# Altium schematic sheet BL702.SchDoc(BL702)
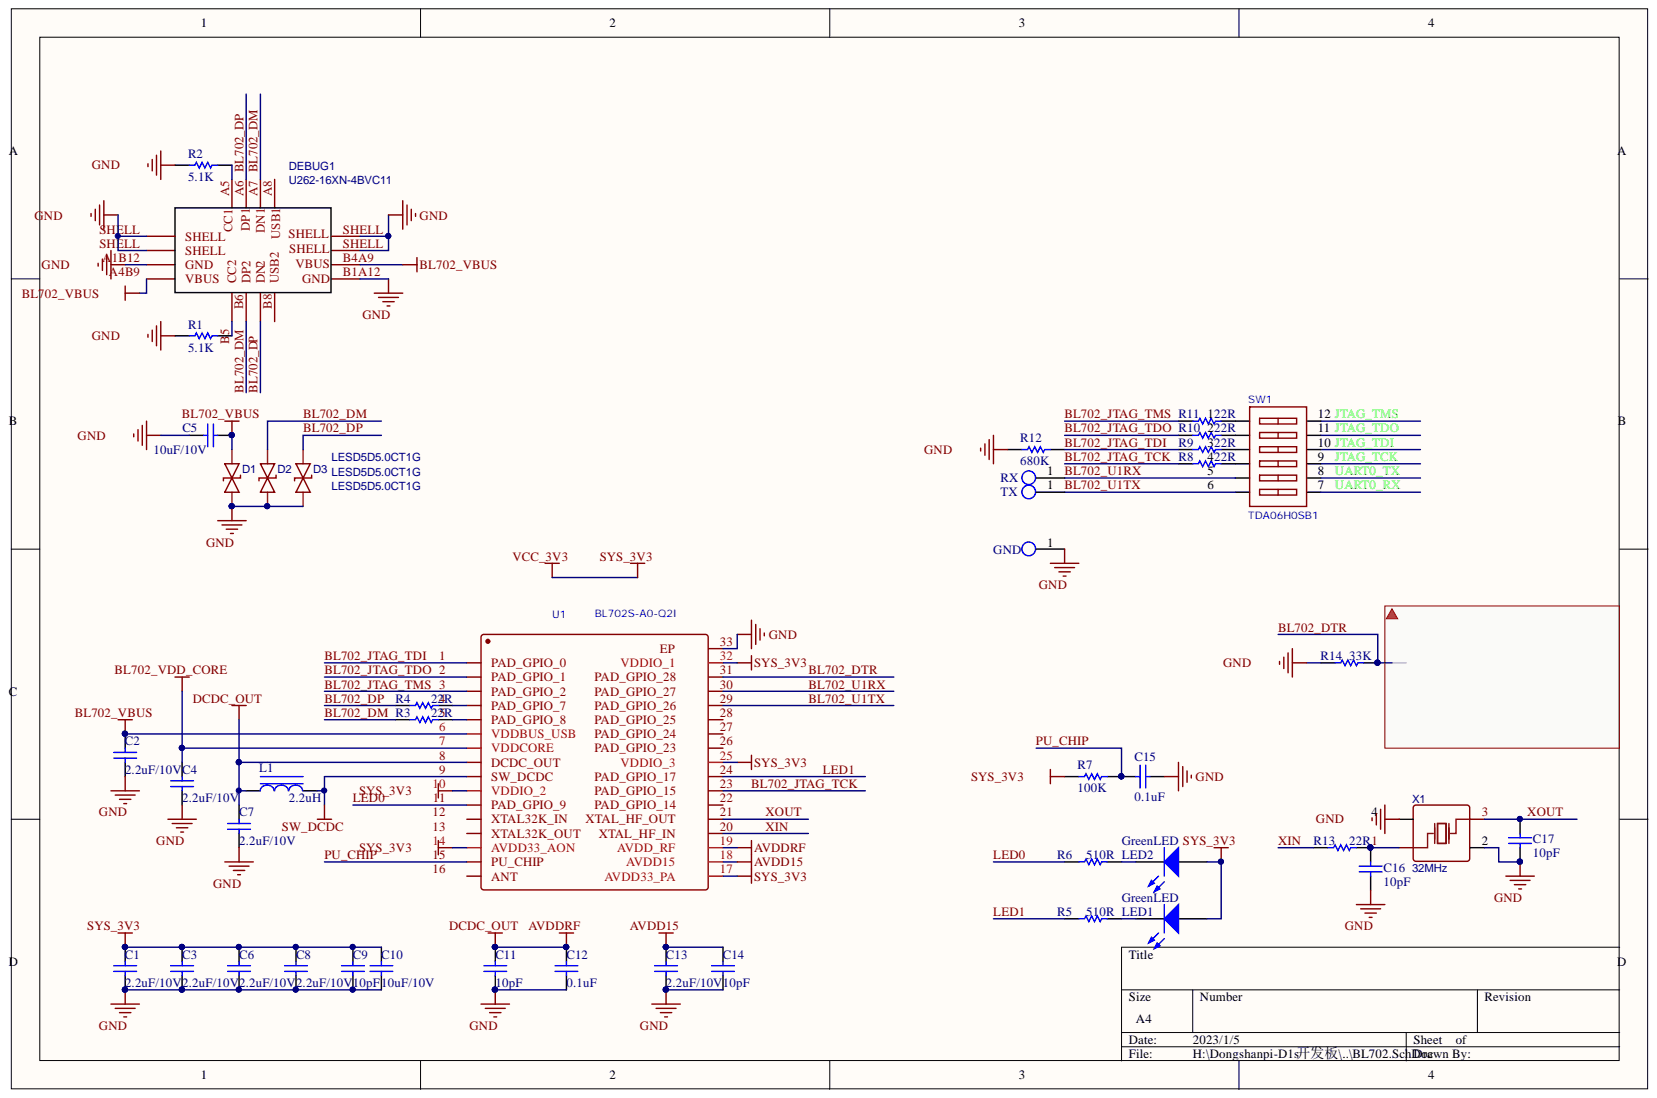
[diagram p0: BL702.SchDoc]

## Parts
C1  2.2uF/10V  [0402]
C2  2.2uF/10V  [0402]
C3  2.2uF/10V  [0402]
C4  2.2uF/10V  [0402]
C5  10uF/10V  [0402]
C6  2.2uF/10V  [0402]
C7  2.2uF/10V  [0402]
C8  2.2uF/10V  [0402]
C9  10pF  [0402]
C10  10uF/10V  [0402]
C11  10pF  [0402]
C12  0.1uF  [0402]
C13  2.2uF/10V  [0402]
C14  10pF  [0402]
C15  0.1uF  [0402]
C16  10pF  [0402]
C17  10pF  [0402]
D1  LESD5D5.0CT1G  [SOD-523_L1.2-W0.8-LS1.6-BI]
D2  LESD5D5.0CT1G  [SOD-523_L1.2-W0.8-LS1.6-BI]
D3  LESD5D5.0CT1G  [SOD-523_L1.2-W0.8-LS1.6-BI]
DEBUG1  U262-16XN-4BVC11  [TYPEC-12PIN - duplicate]
GND  Value  [TP_TH_0.8_1.4]  (value not drawn)
L1  2.2uH  [VLS2016]
LED1  GreenLED  [LED0402]
LED2  GreenLED  [LED0402]
R1  5.1K  [0402]
R2  5.1K  [0402]
R3  22R  [0402]
R4  22R  [0402]
R5  510R  [0402]
R6  510R  [0402]
R7  100K  [0402]
R8  22R  [0402]
R9  22R  [0402]
R10  22R  [0402]
R11  22R  [0402]
R12  680K  [0402]
R13  22R  [0402]
R14  33K  [0402]
RX  Value  [TP_TH_0.8_1.4]  (value not drawn)
SW1  TDA06H0SB1  [SW-SMD_TDA06H0SB1]
TX  Value  [TP_TH_0.8_1.4]  (value not drawn)
U1  BL702S-A0-Q2I  [QFN-32_L4.0-W4.0-P0.40-TL-EP2.9]
X1  32MHz  [OSC-SMD_4P-L3.2-W2.5-BL]

## Nets
AVDD15: C13.2 C14.2 U1.18
AVDDRF: C7.2 C11.2 C12.2 L1.2 U1.8 U1.19
BL702_VBUS: C2.2 C5.2 D1.2 DEBUG1.A4B9 DEBUG1.B4A9 U1.6
BL702_VDD_CORE: C4.2 U1.7
GND: C1.1 C2.1 C3.1 C4.1 C5.1 C6.1 C7.1 C8.1 C9.1 C10.1 C11.1 C12.1 C13.1 C14.1 C15.1 C16.1 C17.1 D1.1 D2.1 D3.1 DEBUG1.A1B12 DEBUG1.B1A12 DEBUG1.SHELL GND.1 R1.2 R2.2 R12.1 R14.2 U1.33 X1.2 X1.4
SW_DCDC: L1.1 U1.9
SYS_3V3: C1.2 C3.2 C6.2 C8.2 C9.2 C10.2 LED1.1 LED2.1 R7.1 U1.10 U1.14 U1.17 U1.25 U1.32
BL702_DM: D2.2 DEBUG1.A7 DEBUG1.B7 R3.2
BL702_DP: D3.2 DEBUG1.A6 DEBUG1.B6 R4.2
BL702_DTR: R14.1 U1.31
BL702_JTAG_TCK: R8.2 U1.23
BL702_JTAG_TDI: R9.2 R12.2 U1.1
BL702_JTAG_TDO: R10.2 U1.2
BL702_JTAG_TMS: R11.2 U1.3
BL702_U1RX: RX.1 SW1.5 U1.30
BL702_U1TX: SW1.6 TX.1 U1.29
JTAG_TCK: SW1.9
JTAG_TDI: SW1.10
JTAG_TDO: SW1.11
JTAG_TMS: SW1.12
LED0: R6.1 U1.11
LED1: R5.1 U1.24
PU_CHIP: C15.2 R7.2 U1.15
UART0_RX: SW1.7
UART0_TX: SW1.8
XIN: R13.2 U1.20
XOUT: C17.2 U1.21 X1.3
NetC16_2: C16.2 R13.1 X1.1
NetDEBUG1_A5: DEBUG1.A5 R2.1
NetDEBUG1_B5: DEBUG1.B5 R1.1
NetLED1_2: LED1.2 R5.2
NetLED2_2: LED2.2 R6.2
NetR3_1: R3.1 U1.5
NetR4_1: R4.1 U1.4
NetR8_1: R8.1 SW1.4
NetR9_1: R9.1 SW1.3
NetR10_1: R10.1 SW1.2
NetR11_1: R11.1 SW1.1

Not connected: DEBUG1 A8 B8; U1 12 13 16 22 26 27 28

## Library data of the parts
C1-C17 = Cap: Capacitor; PartNumber: CAP100NF; Component Kind: Standard; LatestRevisionDate: 17-Jul-2002; LatestRevisionNote: Re-released for DXP Platform.; Library Name: Miscellaneous Devices.IntLib; PackageReference: 0402; Published: 8-Jun-2000; Signal Integrity: Cap; Simulation: CAP; Publisher: Altium Limited
  C1-C4 C6-C8 C13: Value: 2.2uF/10V
  C5 C10: Value: 10uF/10V
  C9 C11 C14 C16 C17: Value: 10pF
  C12 C15: Value: 0.1uF
D1-D3 = LESD5D5.0CT1G: BOM_Manufacturer: Leshan Radio; Contributor: LCSC; BOM_JLCPCB Part Class: Extended Part; BOM_Manufacturer Part: LESD5D5.0CT1G; BOM_Supplier: LCSC; BOM_Supplier Part: C383211; Component Kind: Standard; spicePre: D; spiceSymbolName: LESD5D5.0CT1G
DEBUG1 = U262-16XN-4BVC11: BOM_Manufacturer: XKB Enterprise; Contributor: LCSC; BOM_Manufacturer Part: U262-16XN-4BVC11; BOM_Supplier: LCSC; BOM_Supplier Part: C319148; Component Kind: Standard; Library Name: HM2.SchLib; spicePre: U; spiceSymbolName: U262-16XN-4BVC11
GND RX TX = TP: Component Kind: Standard; Library Name: HM2.SchLib
L1 = L: 普通电感; Component Kind: Standard; Library Name: LIB_MANGO.IntLib
LED1 LED2 = LED2: Typical RED, GREEN, YELLOW, AMBER GaAs LED; Component Kind: Standard; LatestRevisionDate: 17-Jul-2002; LatestRevisionNote: Re-released for DXP Platform.; Library Name: Miscellaneous Devices.IntLib; PackageDescription: SM LED; 2 Flat Leads; PackageReference: 3.2X1.6X1.1; PackageVersion: Feb-2002; Published: 8-Jun-2000; Simulation: LED2; Value: GreenLED; Publisher: Altium Limited
R1 R2 R5-R7 = Res1: Resistor; PartNumber: RES2.2K; Component Kind: Standard; LatestRevisionDate: 17-Jul-2002; LatestRevisionNote: Re-released for DXP Platform.; Library Name: Miscellaneous Devices.IntLib; PackageReference: 0402; Published: 8-Jun-2000; Signal Integrity: Res; Simulation: RESISTOR; Publisher: Altium Limited
  R1 R2: Value: 5.1K
  R5 R6: Value: 510R
  R7: Value: 100K
R3 R4 R8-R14 = Res1: Resistor; PartNumber: RES22R; Component Kind: Standard; LatestRevisionDate: 17-Jul-2002; LatestRevisionNote: Re-released for DXP Platform.; Library Name: Miscellaneous Devices.IntLib; PackageReference: 0402; Published: 8-Jun-2000; Signal Integrity: Res; Simulation: RESISTOR; Publisher: Altium Limited
  R3 R4 R8-R11 R13: Value: 22R
  R12: Value: 680K
  R14: Value: 33K
X1 = 24MHz_1: BOM_Manufacturer: JGHC; BOM_Manufacturer Part: S3224000151050; Contributor: LCSC; nameAlias: Frequency; BOM_Supplier: LCSC; BOM_Supplier Part: C426976; Component Kind: Standard; Library Name: HM2.SchLib; spicePre: X; spiceSymbolName: S3224000151050
SW1 = TDA06H0SB1: Component Kind: Standard; JLC NO: C221986; Library Name: HM2.SchLib
U1 = BL702S-A0-Q2I: Component Kind: Standard
